# Altium schematic sheet LED strip driver.SchDoc
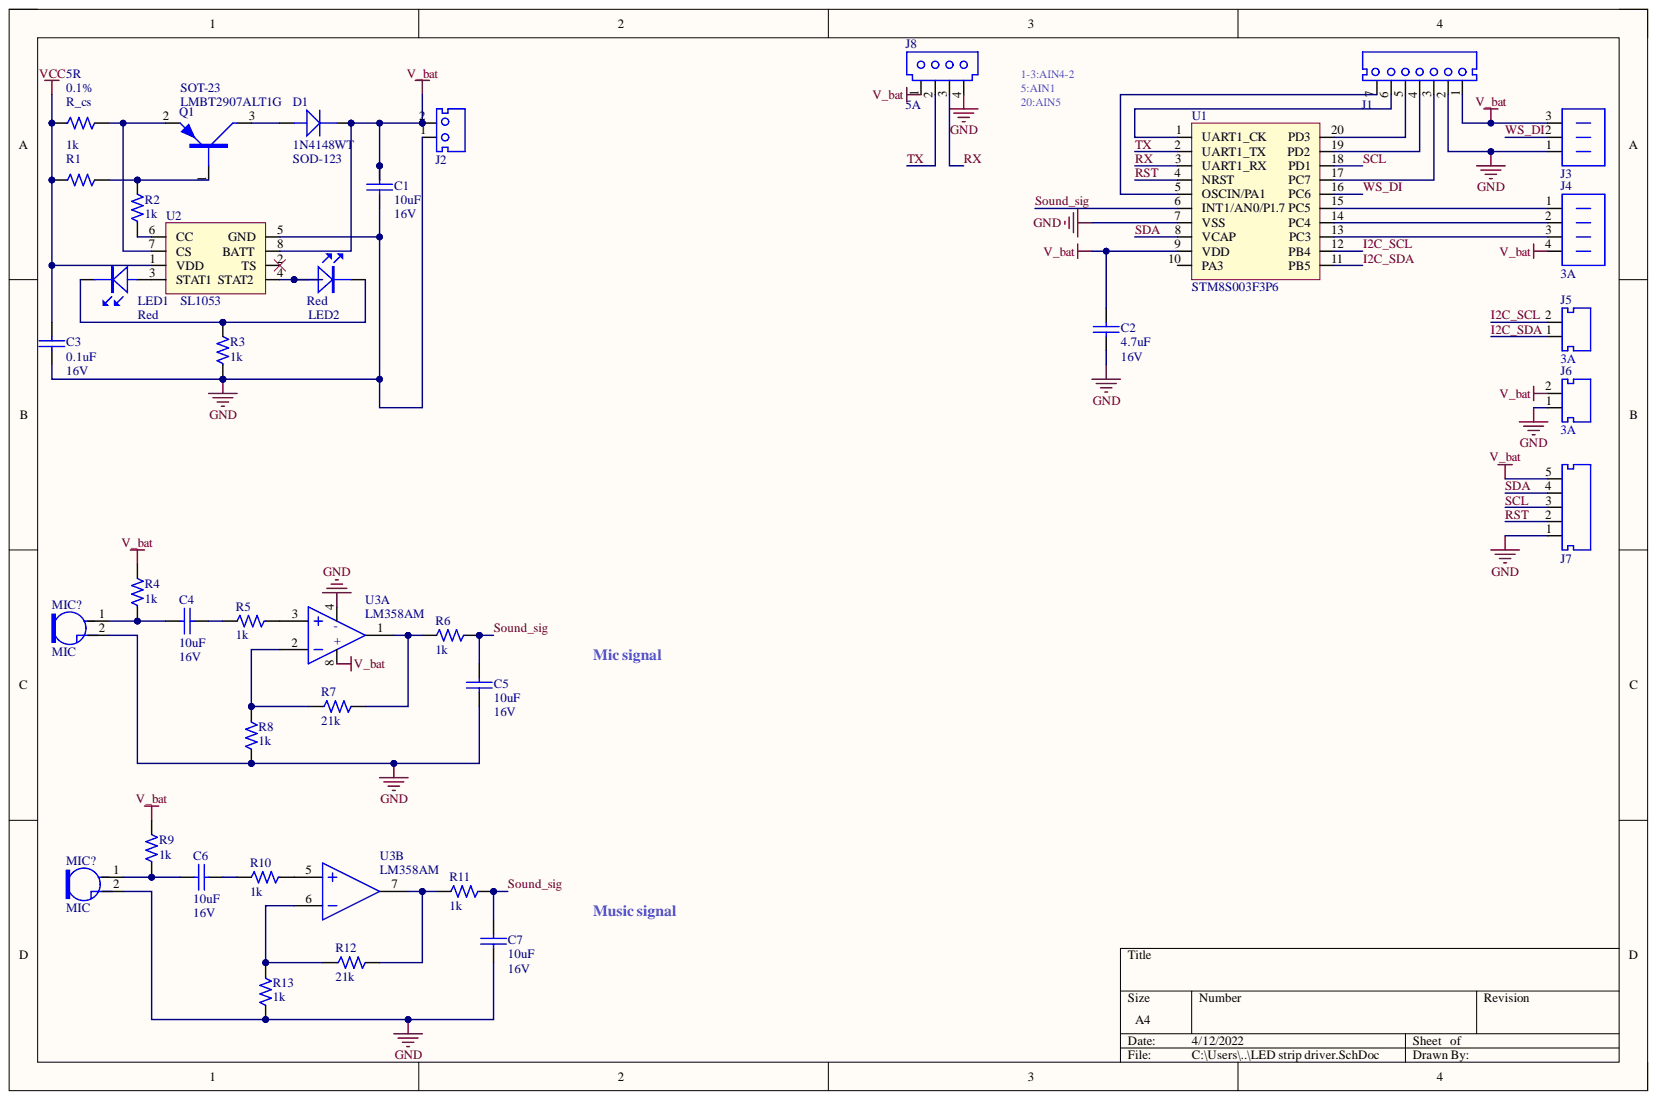
[diagram p0: LED strip driver.SchDoc]

## Parts
C1  value 10uF  Voltage: 16V  [CAPC0402-RW]  (hidden comment: T542011037308)
C2  value 4.7uF  Voltage: 16V  [CAPC0402-RW]  (hidden comment: T542011037308)
C3  value 0.1uF  Voltage: 16V  [CAPC0402-RW]  (hidden comment: T542011037308)
C4  value 10uF  Voltage: 16V  [CAPC0402-RW]  (hidden comment: T542011037308)
C5  value 10uF  Voltage: 16V  [CAPC0402-RW]  (hidden comment: T542011037308)
C6  value 10uF  Voltage: 16V  [CAPC0402-RW]  (hidden comment: T542011037308)
C7  value 10uF  Voltage: 16V  [CAPC0402-RW]  (hidden comment: T542011037308)
D1  1N4148WT  Package: SOD-123  [SOD-523]
J1  CCN250000-0107  [CONN 7P PH=2.5MM ST WAFER]  (value not drawn)
J2  T690300125300  [CONN 2P PH=2.5mm HAA250A-2 WHITE]  (value not drawn)
J3  CCN250000-0503  [CONN 3P PH=2.5mm HAA250A-3 90]  (value not drawn)
J4  Current: 3A  [CONN 4P PH=2.5mm RA WHITE HAA250A-4]  (hidden comment: CCN250010-0504)
J5  Current: 3A  [CONN 2P PH=2.5mm B2B-XH-A]  (hidden comment: CCN250000-0102)
J6  Current: 3A  [CONN 2P PH=2.5mm B2B-XH-A]  (hidden comment: CCN250000-0102)
J7  T690300112400  [CONN 5P PH=2.5mm TJC3-5A-CS]  (value not drawn)
J8  Current: 5A  [CONN 4P PH=3.96mm_VH-4A-CS-HF]  (hidden comment: T690300129900)
LED1  Color: Red  [LED1206-RED]  (hidden comment: LED1206R_23-21\R6C-AM1N2AY\2A)
LED2  Color: Red  [LED1206-RED]  (hidden comment: LED1206R_23-21\R6C-AM1N2AY\2A)
MIC?  MIC  [MIC-6022]
MIC?  MIC  [MIC-6022]
Q1  Package: SOT-23  [SOT23-132-RW]  (hidden comment: RCPA02907-0001)
R1  value 1k  [0402-RW]  (hidden comment: QCF167240-1000)
R2  value 1k  [0402-RW]  (hidden comment: QCF167240-1000)
R3  value 1k  [0402-RW]  (hidden comment: QCF167240-1000)
R4  value 1k  [0402-RW]  (hidden comment: QCF167240-1000)
R5  value 1k  [0402-RW]  (hidden comment: QCF167240-1000)
R6  value 1k  [0402-RW]  (hidden comment: QCF167240-1000)
R7  value 21k  [0402-RW]  (hidden comment: QCF167240-1000)
R8  value 1k  [0402-RW]  (hidden comment: QCF167240-1000)
R9  value 1k  [0402-RW]  (hidden comment: QCF167240-1000)
R10  value 1k  [0402-RW]  (hidden comment: QCF167240-1000)
R11  value 1k  [0402-RW]  (hidden comment: QCF167240-1000)
R12  value 21k  [0402-RW]  (hidden comment: QCF167240-1000)
R13  value 1k  [0402-RW]  (hidden comment: QCF167240-1000)
R_cs  value 5R  TOL: 0.1%  [0402-RW]  (hidden comment: QCF167240-1000)
U1  MFG Part: STM8S003F3P6  [TSSOP20]  (hidden comment: RCI800336-0001)
U2  MFG Part: SL1053  [SO8]  (hidden comment: T610100084118)
U3  RCI000358-0001  [SO8]  (value not drawn)  (parts A,B)

## Nets
GND: C1.2 C2.2 C3.2 C5.1 C7.1 J1.2 J2.1 J3.1 J6.1 J7.1 J8.4 MIC?.2 R3.1 R8.2 R13.2 U1.7(VSS) U2.5(GND) U3.4
V_bat: C1.1 C2.1 D1.2 J1.1 J2.2 J3.3 J4.4 J6.2 J7.5 J8.1 R4.2 R9.2 U1.9(VDD) U2.8(BATT) U3.8(+)
VCC: C3.1 R1.1 R_cs.1 U2.1(VDD)
I2C_SCL: J5.2 U1.12(PB4)
I2C_SDA: J5.1 U1.11(PB5)
RST: J7.2 U1.4(NRST)
RX: J8.3 U1.3(UART1_RX)
SCL: J7.3 U1.18(PD1)
SDA: J7.4 U1.8(VCAP)
Sound_sig: C5.2 C7.2 R6.1 R11.1 U1.6(INT1/AN0/P1.7)
TX: J8.2 U1.2(UART1_TX)
WS_DI: J3.2 U1.16(PC6)
NetC4_1: C4.1 MIC?.1 R4.1
NetC4_2: C4.2 R5.2
NetC6_1: C6.1 MIC?.1 R9.1
NetC6_2: C6.2 R10.2
NetD1_1: D1.1 Q1.3
NetJ1_3: J1.3 U1.17(PC7)
NetJ1_4: J1.4 U1.19(PD2)
NetJ1_5: J1.5 U1.20(PD3)
NetJ1_6: J1.6 U1.1(UART1_CK)
NetJ1_7: J1.7 U1.5(OSCIN/PA1)
NetJ4_1: J4.1 U1.15(PC5)
NetJ4_2: J4.2 U1.14(PC4)
NetJ4_3: J4.3 U1.13(PC3)
NetLED1_1: LED1.1 U2.3(STAT1)
NetLED1_2: LED1.2 LED2.2 R3.2
NetLED2_1: LED2.1 U2.4(STAT2)
NetQ1_1: Q1.1 R1.2 R2.2
NetQ1_2: Q1.2 R_cs.2 U2.7(CS)
NetR2_1: R2.1 U2.6(CC)
NetR5_1: R5.1 U3.3
NetR6_2: R6.2 R7.2 U3.1
NetR7_1: R7.1 R8.1 U3.2
NetR10_1: R10.1 U3.5
NetR11_2: R11.2 R12.2 U3.7
NetR12_1: R12.1 R13.1 U3.6

Not connected: U1 10(PA3); U2 2(TS)

## Library data of the parts
C1-C7 = CAP0402 0.01uF 16V 10%_X7R_WALSIN: CAP X7R .01UF 16V +-10% 0402 WALSIN L; MFG Part: 0402B103K160; CREATE_NAME: Nathan; DIP&SMD: SMD; Tolerance: 10%; Type: Capacitor; Component Kind: Standard; CREATE_TIME: 10-Jan-2020; Customer Specific: N/A; Library Name: P-Capacitors.IntLib; MFG: WALSIN; Other: X7R; PACKAGE: CAPC0402; SAP: T542011037308
D1 = 1N4148WT: DIODE VRM 75V VF 1V  150mA SOD-523F; CREATE_NAME: Nathan; Current: 1A; DIP&SMD: SMD; MFG: DIODES; Component Kind: Standard; CREATE_TIME: 23-Jun-2020; Customer Specific: N/A; Library Name: R-Semiconductors.IntLib; MFG Part: 1N4148WT; Type: DIODE; Voltage: 75V
J1 = CONN 7P PH=2.5mm B4B-XH-A ST: GP CONNECTOR B4B-XH-A 7 PIN G; CREATE_NAME: Nathan; DIP&SMD: DIP; MFG: 金瑞康; SAP: T690300029200; Component Kind: Standard; CREATE_TIME: 24-Sep-2014; Library Name: C-Connector.IntLib; MFG Part: B4B-XH-A; Type: Connector
J2 = CONN 2P PH=2.5mm HAA250A-2 BLACK: CONNECTOR HA2.5 2PIN BLACK K; CREATE_NAME: Nathan; Current: 3A; DIP&SMD: DIP; MFG: 金瑞康; Value: 2.5mm; Component Kind: Standard; CREATE_TIME: 24-Nov-2017; Library Name: C-Connector.IntLib; MFG Part: HAA250A-2 BLACK; SAP: T690300125300; Type: Connector
J3 = CONN 3P PH=2.5mm HAA250A-3 90: CONNECTOR 3PIN P=2.5 White 90'; CREATE_NAME: TOM@Deftronics; DIP&SMD: DIP; MFG: 金科基; SAP: T690300023700; Component Kind: Standard; CREATE_TIME: 16-Apr-2014; Library Name: C-Connector.IntLib; Type: Connector
J4 = CONN 4P PH=2.5mm  RA WHITE HAA250A-4: CONN. 4P P=2.5mm 90' HAA250A-4 RA WHITE; MFG Part: HAA250A-4 WHITE; DIP&SMD: DIP; MFG: 金科基; SAP: T690300038000; Value: 2.5mm; Component Kind: Standard; Library Name: C-Connector.IntLib; Type: Connector
J5 J6 = CONN 2P PH=2.5mm B2B-XH-A: GP CONNECTOR B2B-XH-A 2 PIN G; MFG Part: HAA250A-2A-WHITE; DIP&SMD: DIP; MFG: 金瑞康; SAP: T690300041200; Value: 2.5mm; Vendor Code: 10009989; Vendor Name: 亿源电子有限公司; Component Kind: Standard; Library Name: C-Connector.IntLib; Type: Connector
J7 = CONN 5P PH=2.5mm TJC3-5A-CS: CONNECTOR 5PIN P:2.5MM WHITE M180 K; CREATE_NAME: Nathan; DIP&SMD: DIP; MFG: JIN JIA; Value: 2.5mm; Component Kind: Standard; CREATE_TIME: 18-July-2017; Library Name: C-Connector.IntLib; MFG Part: TJC3-5A-CS; SAP: T690300112400; Type: Connector
J8 = CONN 4P PH=3.96mm VH-4A-CS-HF: CONN POWER 4PIN 3.96MM FM180 L; CREATE_NAME: Nathan; DIP&SMD: DIP; MFG: JST; Component Kind: Standard; CREATE_TIME: 01-Nov-2018; Library Name: C-Connector.IntLib; MFG Part: VH-4A-CS-HF; SAP: T690300129900; Type: Connector; Value: 3.96mm
LED1 LED2 = LED1206R_23-21\R6C-AM1N2AY\2A: CHIP LED RED TYPE 1206; CREATE_NAME: Nathan; DIP&SMD: SMD; MFG: EVERLIGHT; Component Kind: Standard; CREATE_TIME: 18-Aug-2020; Library Name: K-LED-FUSE.IntLib; MFG Part: 23-21\R6C-AM1N2AY\2A; Package: 1206; Type: LED
Q1 = LMBT2907ALT1G: PNP CHIP TRANSISTOR PMBT2907 SOT-23; DIP&SMD: SMD; MFG: LRC; SAP: T582002610437; Vendor Code: 10009207; Vendor Name: MOBICON HOLDINGS LTD.; Component Kind: Standard; Customer Specific: N/A; Library Name: R-Semiconductors.IntLib; MFG Part: LMBT2907ALT1G; Type: PNP
R1-R13 R_cs = RES0402 1/16W 0.1% 100 OHM: CHIP RES 100 OHM 1/16W 0.1% 0402; CREATE_NAME: TOM@Deftronics; DIP&SMD: SMD; SAP: T501100001129; Component Kind: Standard; CREATE_TIME: 05-Jun-2014; Customer Specific: N/A; Library Name: Q-Resistors.IntLib; Package: 0402; Power: 1/16W; Type: Resistor
U1 = N76E003: IC MCU 20P TSSOP20; CREATE_NAME: GordonL@Deftronics; DIP&SMD: SMD; MFG: ST; SAP: T610300083725; Component Kind: Standard; CREATE_TIME: 23-Mar-2014; Customer Specific: N/A; Library Name: R-Semiconductors.IntLib; Type: IC
U2 = MX25L5121EMC-20G: MEMORY MX25L5121EMC-2 SOP-8 MXIC L; CREATE_NAME: Nathan; DIP&SMD: SMD; Component Kind: Standard; CREATE_TIME: 27-Mar-2019; Customer Specific: N/A; Library Name: R-Semiconductors.IntLib; MFG: MXIC; SAP: T610100084118; Type: IC
U3 = LM358AM: IC OP-AMP Dual LM358 DUAL OP-AMP SOIC8; DIP&SMD: SMD; MFG: National SEMI; SAP: T610500008001; Vendor Code: 10020355; Vendor Name: SERIAL MICROELECTRONICS (HK) LIMITE; Component Kind: Standard; Customer Specific: N/A; Library Name: R-Semiconductors.IntLib; MFG Part: LM358AM; Type: IC AMP
MIC? MIC? = MIC: Component Kind: Standard; Library Name: Explorer STM32F407封装库.IntLib
